# Altium schematic sheet 音频.schdoc(音频)
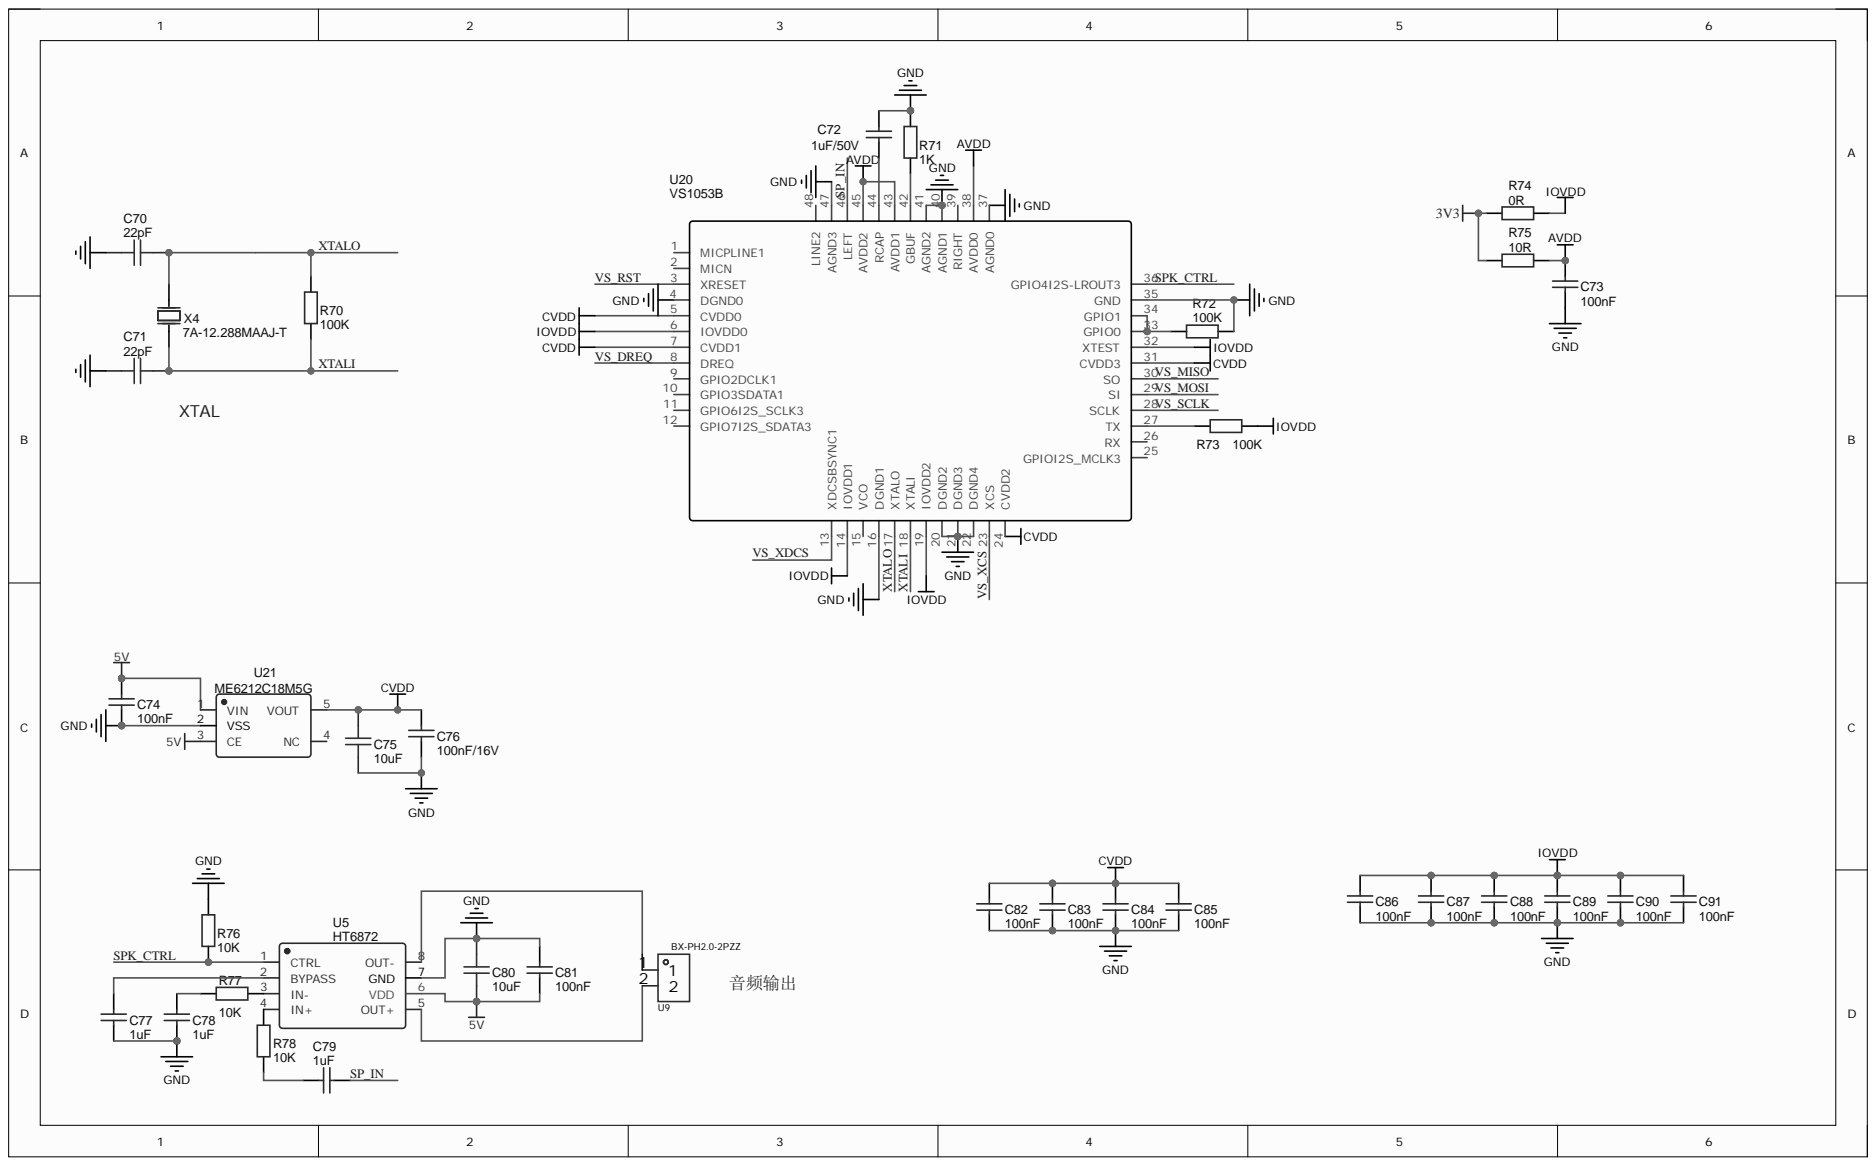
[diagram p0: 音频.schdoc]

## Parts
C70  22pF  [C0603]
C71  22pF  [C0603]
C72  1uF/50V  [C0603]
C73  100nF  [C0603]
C74  100nF  [C0603]
C75  10uF  [C0603]
C76  100nF/16V  [C0603]
C77  1uF  [C0603]
C78  1uF  [C0603]
C79  1uF  [C0603]
C80  10uF  [C0603]
C81  100nF  [C0603]
C82  100nF  [C0603]
C83  100nF  [C0603]
C84  100nF  [C0603]
C85  100nF  [C0603]
C86  100nF  [C0603]
C87  100nF  [C0603]
C88  100nF  [C0603]
C89  100nF  [C0603]
C90  100nF  [C0603]
C91  100nF  [C0603]
R70  100K  [R0603]
R71  1K  [R0603]
R72  100K  [R0603]
R73  100K  [R0603]
R74  0R  [R0603]
R75  10R  [R0603]
R76  10K  [R0603]
R77  10K  [R0603]
R78  10K  [R0603]
U5  HT6872  [SOP-8_L4.9-W3.9-P1.27-LS6.0-BL]
U9  BX-PH2.0-2PZZ  [CONN-TH_2P-P2.00_BOSSIE_BX-PH2.0-2PZZ]
U20  VS1053B  [LQFP-48_L7.0-W7.0-P0.50-LS9.0-BL]
U21  ME6212C18M5G  [SOT-23-5_L3.0-W1.7-P0.95-LS2.8-BL]
X4  7A-12.288MAAJ-T  [OSC-SMD_L5.0-W3.2]

## Nets
3V3: R74.1 R75.1
5V: C74.1 C80.2 C81.1 U5.6(VDD) U21.1(VIN) U21.3(CE)
AVDD: C73.1 R75.2 U20.38(AVDD0) U20.43(AVDD1) U20.45(AVDD2)
CVDD: C75.2 C76.2 C82.1 C83.1 C84.1 C85.1 U20.5(CVDD0) U20.7(CVDD1) U20.24(CVDD2) U20.31(CVDD3) U21.5(VOUT)
GND: C70.2 C71.2 C72.1 C73.2 C74.2 C75.1 C76.1 C77.1 C78.1 C80.1 C81.2 C82.2 C83.2 C84.2 C85.2 C86.2 C87.2 C88.2 C89.2 C90.2 C91.2 R71.1 R72.1 R76.1 U5.7(GND) U20.4(DGND0) U20.16(DGND1) U20.20(DGND2) U20.21(DGND3) U20.22(DGND4) U20.35(GND) U20.37(AGND0) U20.40(AGND1) U20.41(AGND2) U20.47(AGND3) U21.2(VSS)
IOVDD: C86.1 C87.1 C88.1 C89.1 C90.1 C91.1 R73.1 R74.2 U20.6(IOVDD0) U20.14(IOVDD1) U20.19(IOVDD2) U20.32(XTEST)
SP_IN: C79.1 U20.46(LEFT)
SPK_CTRL: R76.2 U5.1(CTRL) U20.36(GPIO4I2S-LROUT3)
VS_DREQ: U20.8(DREQ)
VS_MISO: U20.30(SO)
VS_MOSI: U20.29(SI)
VS_RST: U20.3(XRESET)
VS_SCLK: U20.28(SCLK)
VS_XCS: U20.23(XCS)
VS_XDCS: U20.13(XDCSBSYNC1)
XTALI: C71.1 R70.2 U20.18(XTALI) X4.1
XTALO: C70.1 R70.1 U20.17(XTALO) X4.2
NetC72_2: C72.2 U20.44(RCAP)
NetC77_2: C77.2 U5.2(BYPASS)
NetC78_2: C78.2 R77.1
NetC79_2: C79.2 R78.1
NetR71_2: R71.2 U20.42(GBUF)
NetR72_2: R72.2 U20.33(GPIO0) U20.34(GPIO1)
NetR73_2: R73.2 U20.27(TX)
NetR77_2: R77.2 U5.3(IN-)
NetR78_2: R78.2 U5.4(IN+)
NetU5_5: U5.5(OUT+) U9.2
NetU5_8: U5.8(OUT-) U9.1

Not connected: U20 1(MICPLINE1) 2(MICN) 9(GPIO2DCLK1) 10(GPIO3SDATA1) 11(GPIO6I2S_SCLK3) 12(GPIO7I2S_SDATA3) 15(VCO) 25(GPIOI2S_MCLK3) 26(RX) 39(RIGHT) 48(LINE2); U21 4(NC)

## Library data of the parts
C70 C71 = 22pF: Manufacturer: TDK; Manufacturer Part: CGA3E2C0G1H220JT0Y0N; Contributor: LCSC; nameAlias: Capacitance; Component Kind: Standard; spicePre: C; spiceSymbolName: CGA3E2C0G1H220JT0Y0N; Supplier: LCSC; Supplier Part: C338053
C72 = 1uF/50V: Manufacturer: SAMSUNG; Manufacturer Part: CL10A105KB8NNNC; nameAlias: Capacitance; Component Kind: Standard; JLCPCB Part Class: Basic Part; LCSC Assembly: Yes; spicePre: C; spiceSymbolName: CL10A105KB8NNNC; Supplier: LCSC; Supplier Part: C15849
C73 C74 C81-C91 = 100nF: Manufacturer: FH; Manufacturer Part: 0603F104M500NT; nameAlias: Capacitance; Component Kind: Standard; JLCPCB Part Class: Extended Part; spicePre: C; spiceSymbolName: 0603F104M500NT; Supplier: LCSC; Supplier Part: C42998
C75 C80 = 10uF: Manufacturer: SAMSUNG; Manufacturer Part: CL10A106MP8NNNC; nameAlias: Capacitance; Component Kind: Standard; JLCPCB Part Class: Extended Part; spicePre: C; spiceSymbolName: CL10A106MP8NNNC; Supplier: LCSC; Supplier Part: C85713
C76 = 100nF/16V: Manufacturer: FH; Manufacturer Part: 0603F104M500NT; nameAlias: Capacitance; Component Kind: Standard; JLCPCB Part Class: Extended Part; spicePre: C; spiceSymbolName: 0603F104M500NT; Supplier: LCSC; Supplier Part: C42998
C77-C79 = 1uF: Manufacturer: SAMSUNG; Manufacturer Part: CL10A105KB8NNNC; nameAlias: Capacitance; Component Kind: Standard; JLCPCB Part Class: Basic Part; LCSC Assembly: Yes; spicePre: C; spiceSymbolName: CL10A105KB8NNNC; Supplier: LCSC; Supplier Part: C15849
R70 R72 R73 = 100K: Manufacturer: UniOhm; Manufacturer Part: 0603WAF1002T5E; nameAlias: Resistance (Ohms); SMT Type: Basic; Component Kind: Standard; JLCPCB Part Class: Basic Part; LCSC Assembly: Yes; spicePre: R; spiceSymbolName: 0603WAF1002T5E; Supplier: LCSC; Supplier Part: C25804
R71 = 1K: Manufacturer: UniOhm; Manufacturer Part: 0603WAF1002T5E; nameAlias: Resistance (Ohms); SMT Type: Basic; Component Kind: Standard; JLCPCB Part Class: Basic Part; LCSC Assembly: Yes; spicePre: R; spiceSymbolName: 0603WAF1002T5E; Supplier: LCSC; Supplier Part: C25804
R74 = 0R: Manufacturer: UniOhm; Manufacturer Part: 0603WAF1002T5E; nameAlias: Resistance (Ohms); SMT Type: Basic; Component Kind: Standard; JLCPCB Part Class: Basic Part; LCSC Assembly: Yes; spicePre: R; spiceSymbolName: 0603WAF1002T5E; Supplier: LCSC; Supplier Part: C25804
R75 = 10R: Manufacturer: UniOhm; Manufacturer Part: 0603WAF1002T5E; nameAlias: Resistance (Ohms); SMT Type: Basic; Component Kind: Standard; JLCPCB Part Class: Basic Part; LCSC Assembly: Yes; spicePre: R; spiceSymbolName: 0603WAF1002T5E; Supplier: LCSC; Supplier Part: C25804
R76-R78 = 10K: Manufacturer: UniOhm; Manufacturer Part: 0603WAF1002T5E; nameAlias: Resistance (Ohms); SMT Type: Basic; Component Kind: Standard; JLCPCB Part Class: Basic Part; LCSC Assembly: Yes; spicePre: R; spiceSymbolName: 0603WAF1002T5E; Supplier: LCSC; Supplier Part: C25804
U5 = HT6872: Manufacturer: HEROIC; Component Kind: Standard; JLCPCB Part Class: Extended Part; Manufacturer Part: HT6872; spicePre: U; spiceSymbolName: HT6872; Supplier: LCSC; Supplier Part: C93112
U9 = BX-PH2.0-2PZZ: Manufacturer: Bossie(博锡); Add into BOM: yes; Current Rating (Max): 2A; Number of PINs Per Row: 2; Pins Structure: 1x2P; Pitch: 2mm; Reference Series: PH; Component Kind: Standard; Contact Material: 黄铜; Contact Plating: 锡; Convert to PCB: yes; Datasheet: https://atta.szlcsc.com/upload/public/pdf/source/20231017/0F5D8A357D6F7BFB4F7225D5BDBA6EB1.pdf; Device: BX-PH2.0-2PZZ; JLCPCB Part Class: Extended Part; LCSC Part Name: BX-PH2.0-2PZZ; Manufacturer Part: BX-PH2.0-2PZZ; Mounting Style: 直插; Number of Pins: 2P; Number of Rows: 1; Operating Temperature Range: -25℃~+85℃; Row Spacing: -; Supplier: LCSC; Supplier Footprint: 插件,P=2mm; Supplier Part: C18077744; Symbol: BX-PH2.0-2PZZ
U20 = VS1053B: Manufacturer: VLSI; sourceId: 1T5FDZYC8; Component Kind: Standard; JLCPCB Part Class: Extended Part; Manufacturer Part: VS1053B; spicePre: U; spiceSymbolName: VS1053B; Supplier: LCSC; Supplier Part: C9922; timeStamp: 1459254824
U21 = ME6212C18M5G: Manufacturer: Nanjing Micro One Elec; Contributor: LCSC; Component Kind: Standard; JLCPCB Part Class: Extended Part; Manufacturer Part: ME6212C18M5G; spicePre: U; spiceSymbolName: ME6212C18M5G; Supplier: LCSC; Supplier Part: C236669
X4 = 7A-12.288MAAJ-T: Manufacturer: TXC(台湾晶技); Contributor: LCSC; Component Kind: Standard; JLCPCB Part Class: Extended Part; Manufacturer Part: 7A-12.288MAAJ-T; spicePre: U; spiceSymbolName: 7A-12.288MAAJ-T; Supplier: LCSC; Supplier Part: C2450244
